# Altium schematic sheet MOSFET.SchDoc
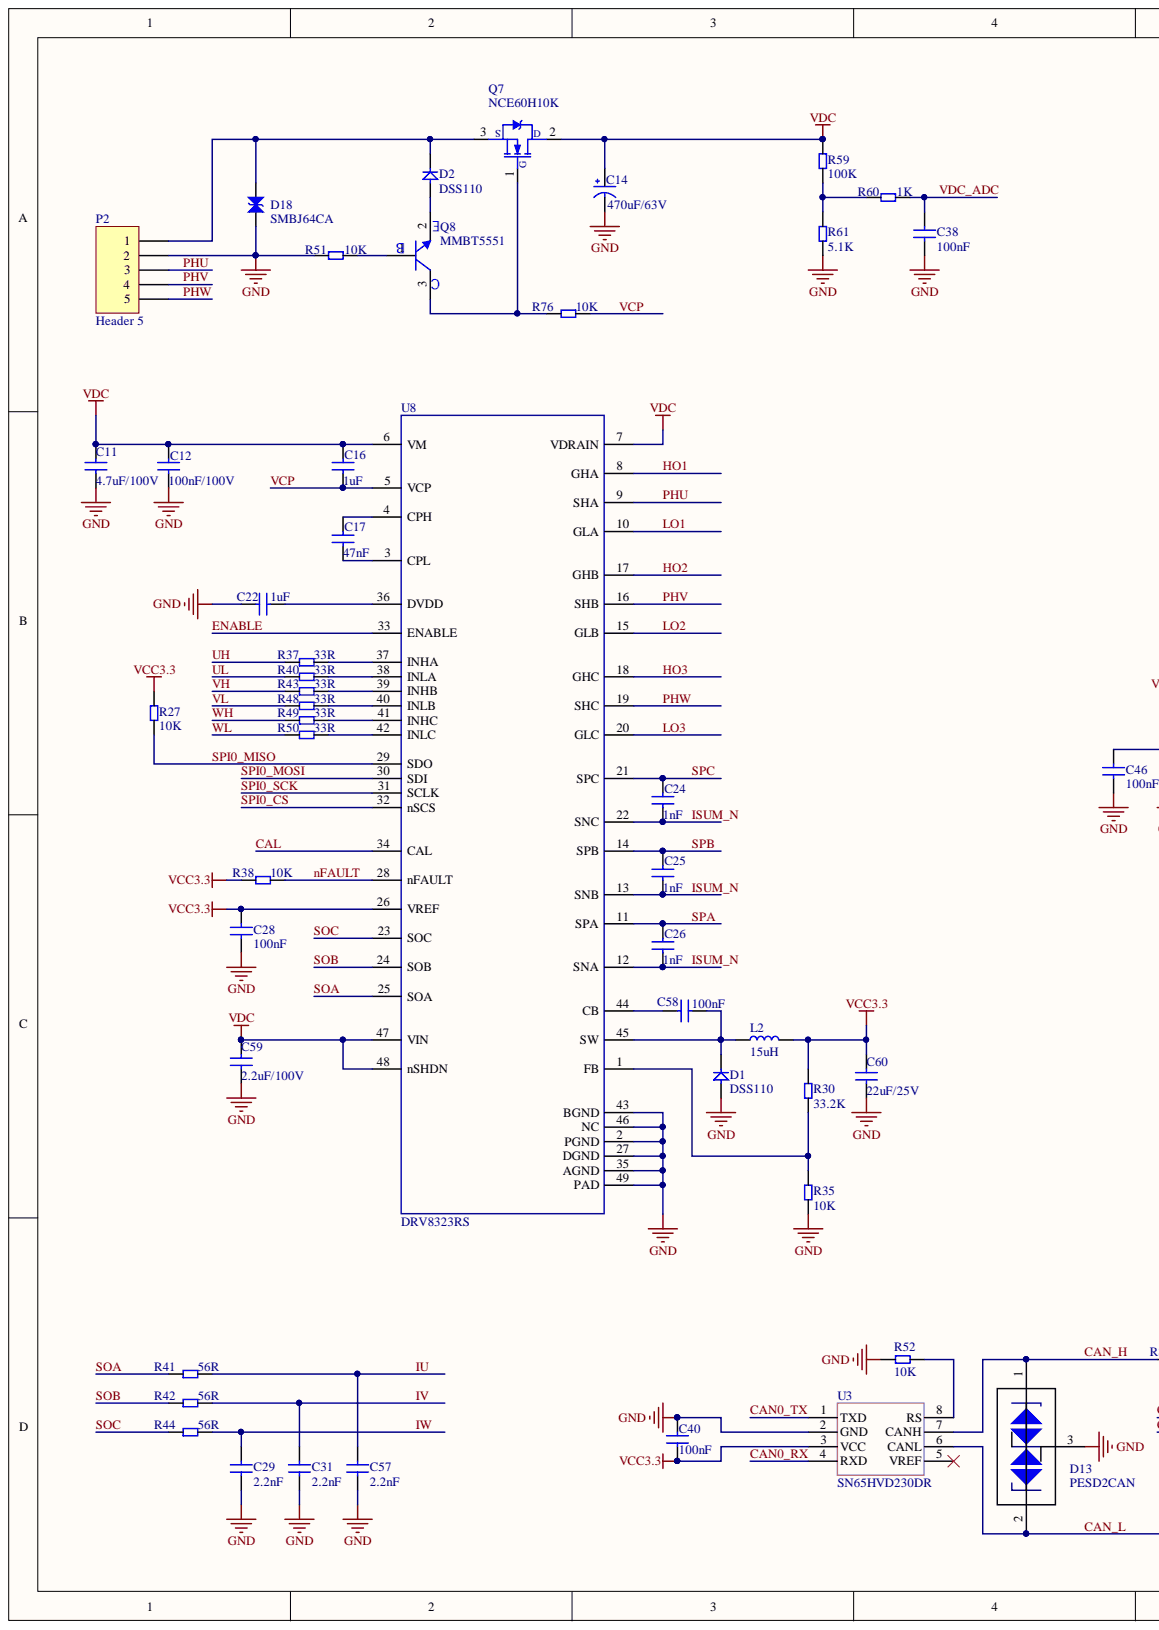
[diagram p0: MOSFET.SchDoc, left]
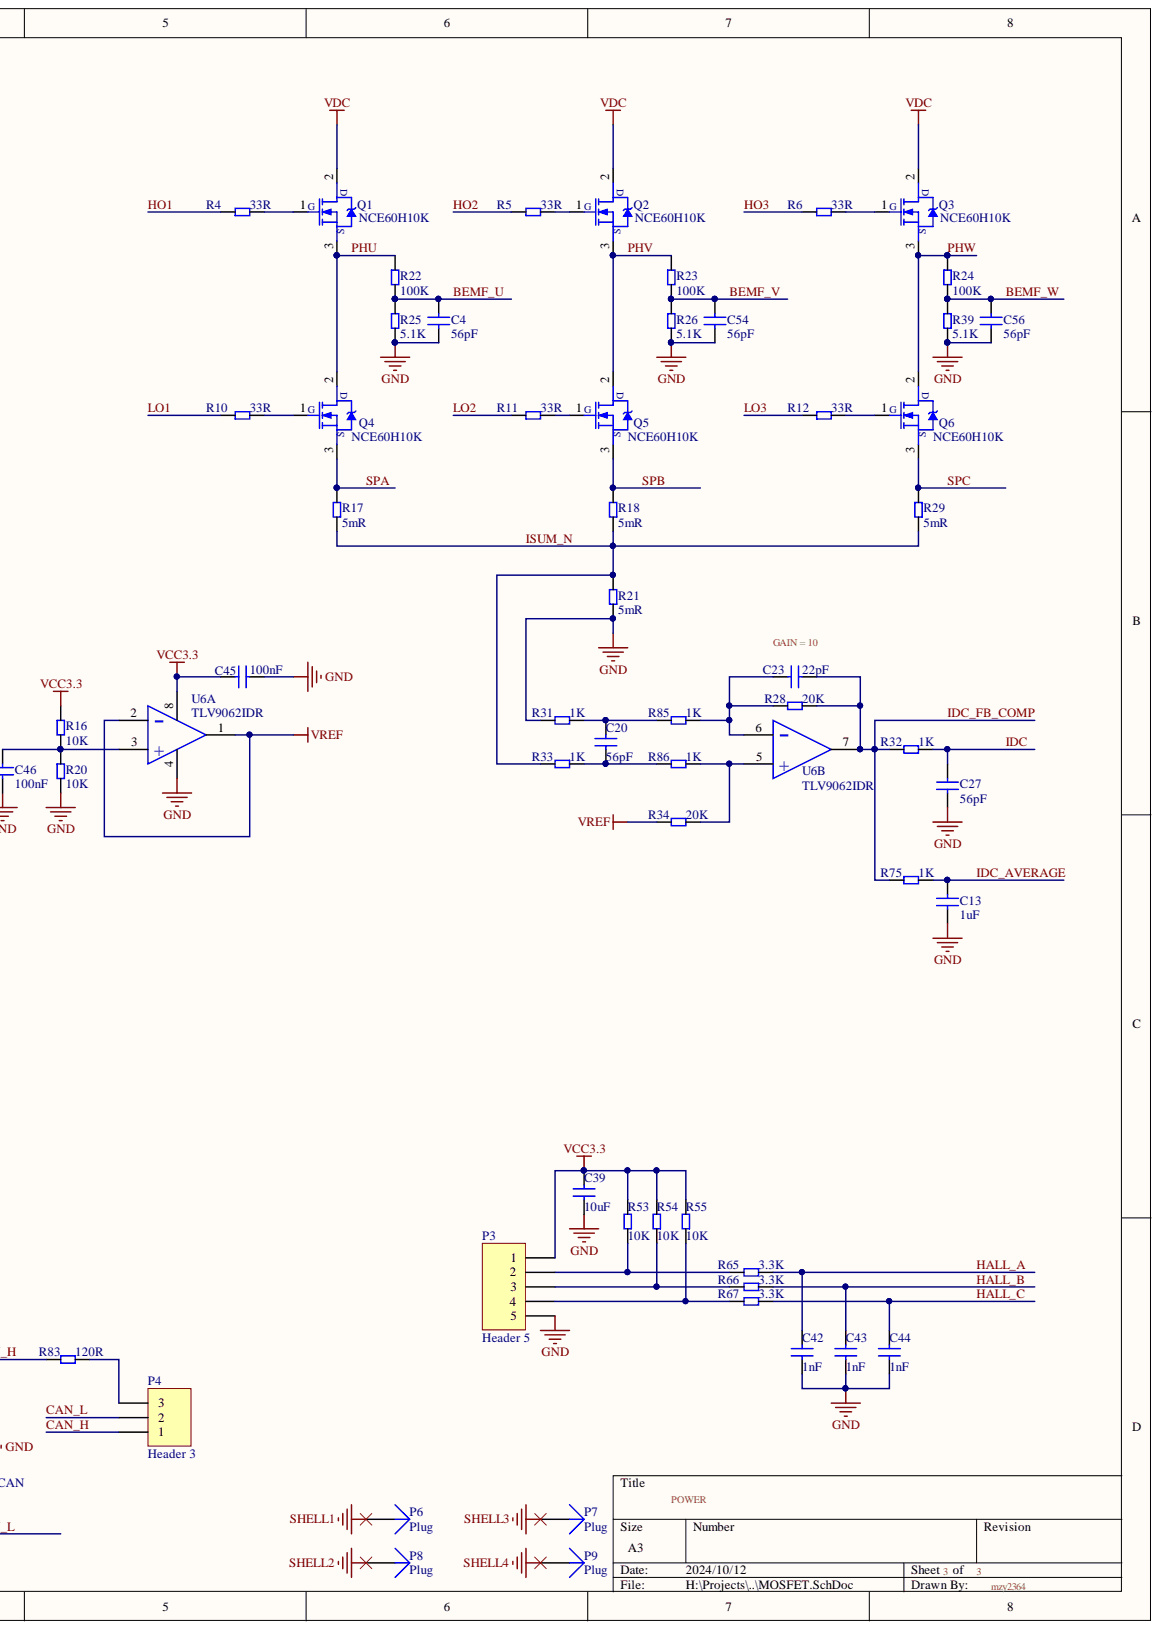
[diagram p1: MOSFET.SchDoc, right]

## Parts
C4  56pF  [C0603]
C11  4.7uF/100V  [C1210]
C12  100nF/100V  [C0805]
C13  1uF  [C0603]
C14  470uF/63V  [CAP 5.0*12.5*25-H_1]
C16  1uF  [C0603]
C17  47nF  [C0603]
C20  56pF  [C0603]
C22  1uF  [C0603]
C23  22pF  [C0603]
C24  1nF  [C0603]
C25  1nF  [C0603]
C26  1nF  [C0603]
C27  56pF  [C0603]
C28  100nF  [C0603]
C29  2.2nF  [C0603]
C31  2.2nF  [C0603]
C38  100nF  [C0603]
C39  10uF  [C0603]
C40  100nF  [C0603]
C42  1nF  [C0603]
C43  1nF  [C0603]
C44  1nF  [C0603]
C45  100nF  [C0603]
C46  100nF  [C0603]
C54  56pF  [C0603]
C56  56pF  [C0603]
C57  2.2nF  [C0603]
C58  100nF  [C0603]
C59  2.2uF/100V  [C1210]
C60  22uF/25V  [C0805]
D1  DSS110  [SOD123]
D2  DSS110  [SOD123]
D13  PESD2CAN  [SOT23]
D18  SMBJ64CA  [SMB]
L2  15uH  [L_SMNR5020]
P2  Header 5  [KF2EDGK5.08-WI-5P_1]
P3  Header 5  [KF2EDG3.81-WI-5P_1]
P4  Header 3  [KF2EDG3.81-W-3P_1]
P6  Plug  [HOLE3.2-6]
P7  Plug  [HOLE3.2-6]
P8  Plug  [HOLE3.2-6]
P9  Plug  [HOLE3.2-6]
Q1  NCE60H10K  [TO252]
Q2  NCE60H10K  [TO252]
Q3  NCE60H10K  [TO252]
Q4  NCE60H10K  [TO252]
Q5  NCE60H10K  [TO252]
Q6  NCE60H10K  [TO252]
Q7  NCE60H10K  [TO252]
Q8  MMBT5551  [SOT23]
R4  33R  [RES0603]
R5  33R  [RES0603]
R6  33R  [RES0603]
R10  33R  [RES0603]
R11  33R  [RES0603]
R12  33R  [RES0603]
R16  10K  [RES0603]
R17  5mR  [RES2512]
R18  5mR  [RES2512]
R20  10K  [RES0603]
R21  5mR  [RES2512]
R22  100K  [RES0603]
R23  100K  [RES0603]
R24  100K  [RES0603]
R25  5.1K  [RES0603]
R26  5.1K  [RES0603]
R27  10K  [RES0603]
R28  20K  [RES0603]
R29  5mR  [RES2512]
R30  33.2K  [RES0603]
R31  1K  [RES0603]
R32  1K  [RES0603]
R33  1K  [RES0603]
R34  20K  [RES0603]
R35  10K  [RES0603]
R37  33R  [RES0402]
R38  10K  [RES0603]
R39  5.1K  [RES0603]
R40  33R  [RES0402]
R41  56R  [RES0603]
R42  56R  [RES0603]
R43  33R  [RES0402]
R44  56R  [RES0603]
R48  33R  [RES0402]
R49  33R  [RES0402]
R50  33R  [RES0402]
R51  10K  [RES0603]
R52  10K  [RES0603]
R53  10K  [RES0603]
R54  10K  [RES0603]
R55  10K  [RES0603]
R59  100K  [RES0603]
R60  1K  [RES0603]
R61  5.1K  [RES0603]
R65  3.3K  [RES0603]
R66  3.3K  [RES0603]
R67  3.3K  [RES0603]
R75  1K  [RES0603]
R76  10K  [RES0603]
R83  120R  [RES0805]
R85  1K  [RES0603]
R86  1K  [RES0603]
U3  SN65HVD230DR  [SOIC8-150MIL]
U6  TLV9062IDR  [SOP8]  (parts A,B)
U8  DRV8323RS  [QFN48-7x7]

## Nets
GND: C4.2 C11.1 C12.1 C13.1 C14.2 C22.2 C27.1 C28.2 C29.1 C31.1 C38.1 C39.1 C40.2 C42.1 C43.1 C44.1 C45.1 C46.2 C54.2 C56.2 C57.1 C59.1 C60.1 D1.1 D13.3 D18.1 P2.2 P3.5 R20.2 R21.1 R25.2 R26.2 R31.2 R35.2 R39.2 R51.2 R52.1 R61.1 U3.2(GND) U6.4 U8.2(PGND) U8.27(DGND) U8.35(AGND) U8.43(BGND) U8.46(NC) U8.49(PAD)
SHELL1: P6.1
SHELL2: P8.1
SHELL3: P7.1
SHELL4: P9.1
VCC3.3: C28.1 C39.2 C40.1 C45.2 C60.2 L2.1 P3.1 R16.1 R27.1 R30.1 R38.2 R53.2 R54.2 R55.2 U3.3(VCC) U6.8 U8.26(VREF)
VDC: C11.2 C12.2 C14.1 C16.2 C59.2 Q1.2 Q2.2 Q3.2 Q7.2 R59.2 U8.6(VM) U8.7(VDRAIN) U8.47(VIN) U8.48(nSHDN)
VREF: R34.2 U6.1 U6.2(-)
BEMF_U: C4.1 R22.2 R25.1
BEMF_V: C54.1 R23.2 R26.1
BEMF_W: C56.1 R24.2 R39.1
CAL: U8.34(CAL)
CAN0_RX: U3.4(RXD)
CAN0_TX: U3.1(TXD)
CAN_H: D13.1 P4.1 R83.2 U3.7(CANH)
CAN_L: D13.2 P4.2 U3.6(CANL)
ENABLE: U8.33(ENABLE)
HALL_A: C42.2 R65.1
HALL_B: C43.2 R66.1
HALL_C: C44.2 R67.1
HO1: R4.1 U8.8(GHA)
HO2: R5.1 U8.17(GHB)
HO3: R6.1 U8.18(GHC)
IDC: C27.2 R32.1
IDC_AVERAGE: C13.2 R75.1
IDC_FB_COMP: C23.1 R28.1 R32.2 R75.2 U6.7
ISUM_N: C24.1 C25.1 C26.1 R17.1 R18.1 R21.2 R29.1 R33.2 U8.12(SNA) U8.13(SNB) U8.22(SNC)
IU: C57.2 R41.2
IV: C31.2 R42.2
IW: C29.2 R44.2
LO1: R10.1 U8.10(GLA)
LO2: R11.1 U8.15(GLB)
LO3: R12.1 U8.20(GLC)
nFAULT: R38.1 U8.28(nFAULT)
PHU: P2.3 Q1.3 Q4.2 R22.1 U8.9(SHA)
PHV: P2.4 Q2.3 Q5.2 R23.1 U8.16(SHB)
PHW: P2.5 Q3.3 Q6.2 R24.1 U8.19(SHC)
SOA: R41.1 U8.25(SOA)
SOB: R42.1 U8.24(SOB)
SOC: R44.1 U8.23(SOC)
SPA: C26.2 Q4.3 R17.2 U8.11(SPA)
SPB: C25.2 Q5.3 R18.2 U8.14(SPB)
SPC: C24.2 Q6.3 R29.2 U8.21(SPC)
SPI0_CS: U8.32(nSCS)
SPI0_MISO: R27.2 U8.29(SDO)
SPI0_MOSI: U8.30(SDI)
SPI0_SCK: U8.31(SCLK)
UH: R37.1
UL: R40.1
VCP: C16.1 R76.1 U8.5(VCP)
VDC_ADC: C38.2 R60.1
VH: R43.1
VL: R48.1
WH: R49.1
WL: R50.1
NetC17_1: C17.1 U8.3(CPL)
NetC17_2: C17.2 U8.4(CPH)
NetC20_1: C20.1 R31.1 R85.2
NetC20_2: C20.2 R33.1 R86.2
NetC22_1: C22.1 U8.36(DVDD)
NetC23_2: C23.2 R28.2 R85.1 U6.6(-)
NetC46_1: C46.1 R16.2 R20.1 U6.3(+)
NetC58_1: C58.1 U8.44(CB)
NetC58_2: C58.2 D1.2 L2.2 U8.45(SW)
NetD2_1: D2.1 Q8.2
NetD2_2: D2.2 D18.2 P2.1 Q7.3
NetP3_2: P3.2 R53.1 R65.2
NetP3_3: P3.3 R54.1 R66.2
NetP3_4: P3.4 R55.1 R67.2
NetP4_3: P4.3 R83.1
NetQ1_1: Q1.1 R4.2
NetQ2_1: Q2.1 R5.2
NetQ3_1: Q3.1 R6.2
NetQ4_1: Q4.1 R10.2
NetQ5_1: Q5.1 R11.2
NetQ6_1: Q6.1 R12.2
NetQ7_1: Q7.1 Q8.3 R76.2
NetQ8_1: Q8.1 R51.1
NetR30_2: R30.2 R35.1 U8.1(FB)
NetR34_1: R34.1 R86.1 U6.5(+)
NetR37_2: R37.2 U8.37(INHA)
NetR40_2: R40.2 U8.38(INLA)
NetR43_2: R43.2 U8.39(INHB)
NetR48_2: R48.2 U8.40(INLB)
NetR49_2: R49.2 U8.41(INHC)
NetR50_2: R50.2 U8.42(INLC)
NetR52_2: R52.2 U3.8(RS)
NetR59_1: R59.1 R60.2 R61.2

Not connected: U3 5(VREF)

## Library data of the parts
C4 C13 C20 C23 C27-C29 C31 C39 C42-C46 C54 C56-C58 = CAP: Material: X7R; Precision: 10%; Voltage: 50V; Component Kind: Standard; Library Name: mzy2364.SchLib
C11 C12 C16 C17 C22 C24-C26 C38 C40 C59 C60 = CAP: Component Kind: Standard; Library Name: mzy2364.SchLib
C14 = C POL: Component Kind: Standard; Library Name: mzy2364.SchLib
D1 D2 = D: Component Kind: Standard; Library Name: mzy2364.SchLib
D18 = D TVS-Double: 双向tvs二极管; Component Kind: Standard; Library Name: mzy2364.SchLib
L2 = L: Component Kind: Standard; Library Name: mzy2364.SchLib
P2 P3 = Header 5: Header, 5-Pin; Component Kind: Standard; LatestRevisionDate: 17-Jul-2002; LatestRevisionNote: Re-released for DXP Platform.; Library Name: Miscellaneous Connectors.IntLib; Signal Integrity: Connector; Publisher: Altium Limited
P4 = Header 3: Header, 3-Pin; Component Kind: Standard; LatestRevisionDate: 17-Jul-2002; LatestRevisionNote: Re-released for DXP Platform.; Library Name: Miscellaneous Connectors.IntLib; Signal Integrity: Connector; Publisher: Altium Limited
Q1-Q7 = NMOS-TO252: Component Kind: Standard; Library Name: mzy2364.SchLib
Q8 = NPN: Component Kind: Standard; Library Name: mzy2364.SchLib
R4-R6 R10-R12 R16-R18 R20-R35 R37-R44 R48-R55 R59-R61 R65-R67 R75 R76 R83 R85 R86 = RES: Component Kind: Standard; Library Name: mzy2364.SchLib
U3 = SN65HVD230DR: 3.3V CAN 总线收发器; Component Kind: Standard; Library Name: mzy2364.SchLib
U6 = AD8656: Component Kind: Standard; Library Name: mzy2364.SchLib
U8 = DRV8323RS: 6V 至 60V 三相智能栅极驱动器; Component Kind: Standard; Library Name: mzy2364.SchLib
D13 = PESD2CAN: Component Kind: Standard; Library Name: EDC17_5644A.SCHLIB
P6-P9 = Plug: Component Kind: Standard; Description: Plug; LatestRevisionDate: 17-Jul-2002; LatestRevisionNote: Re-released for DXP Platform.; Library Name: Miscellaneous Connectors.IntLib; Signal Integrity: Connector; Publisher: Altium Limited
